# Altium schematic sheet I2C.SchDoc(I2C)
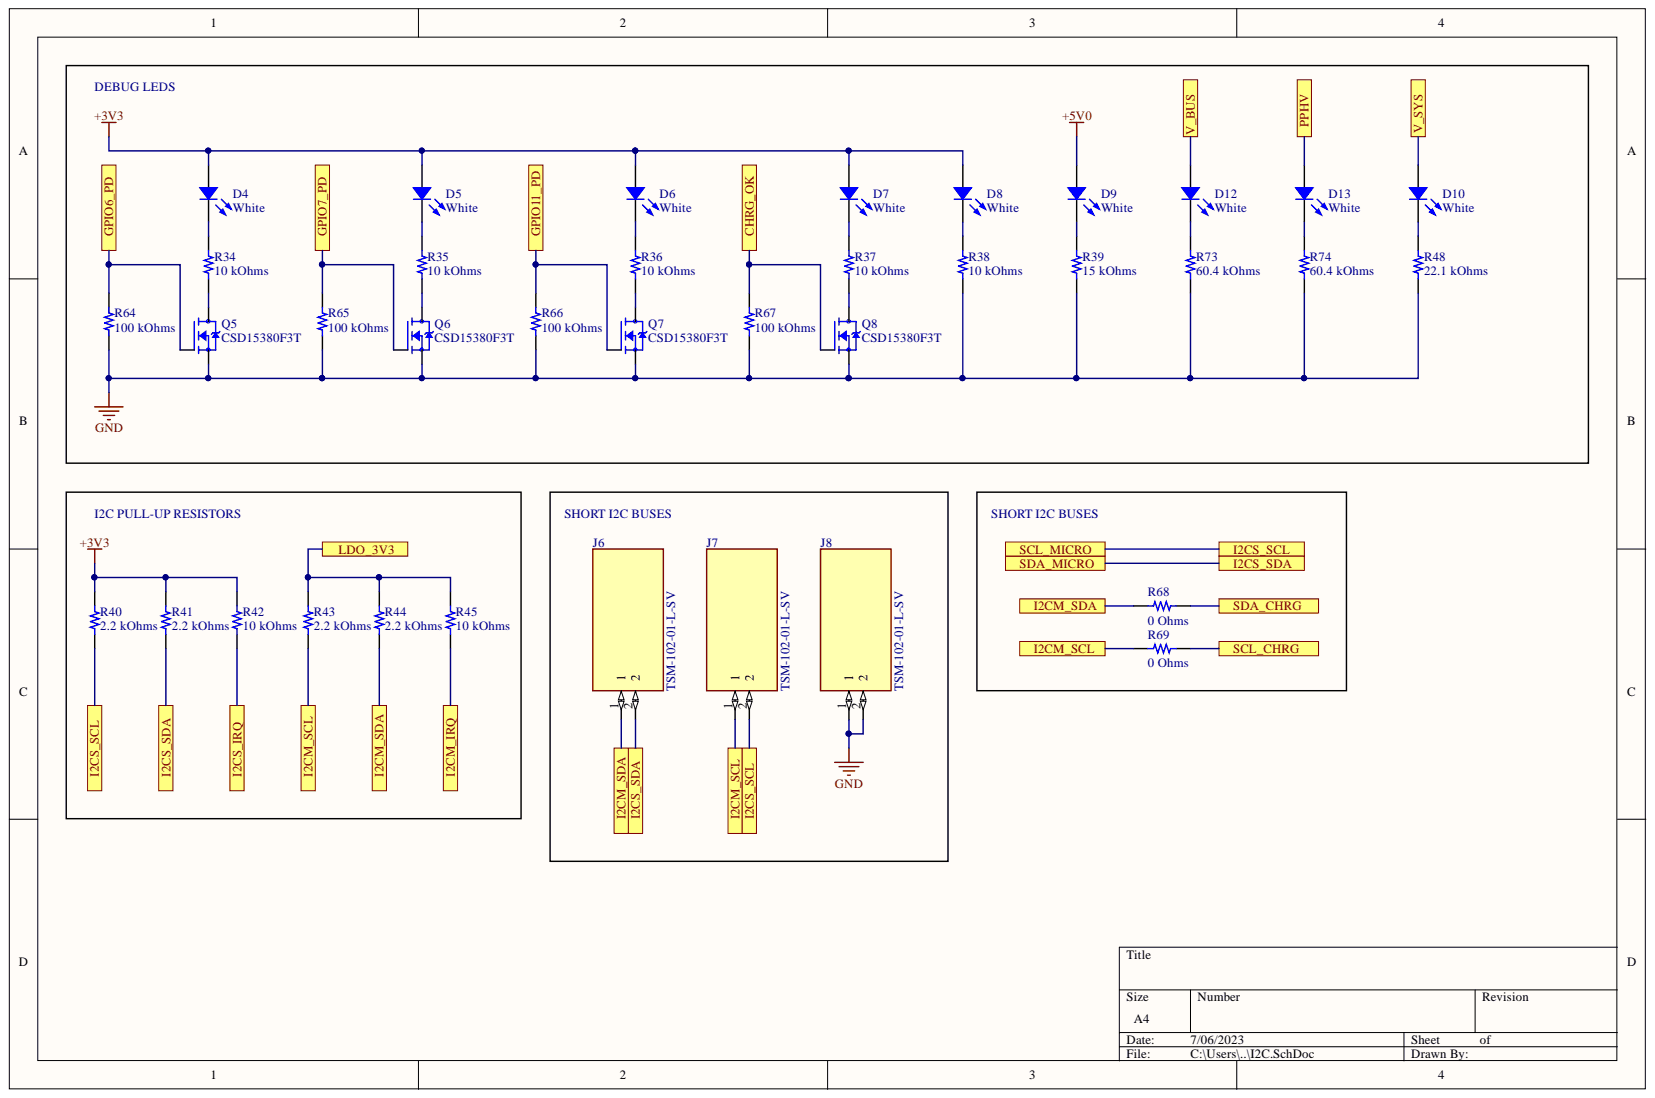
[diagram p0: I2C.SchDoc]

## Parts
D4  White  [LED 0805_2012]
D5  White  [LED 0805_2012]
D6  White  [LED 0805_2012]
D7  White  [LED 0805_2012]
D8  White  [LED 0805_2012]
D9  White  [LED 0805_2012]
D10  White  [LED 0805_2012]
D12  White  [LED 0805_2012]
D13  White  [LED 0805_2012]
J6  TSM-102-01-L-SV  [TSM-102-01-L-SV]
J7  TSM-102-01-L-SV  [TSM-102-01-L-SV]
J8  TSM-102-01-L-SV  [TSM-102-01-L-SV]
Q5  CSD15380F3T  [TRXDFN69X60X35-3N]
Q6  CSD15380F3T  [TRXDFN69X60X35-3N]
Q7  CSD15380F3T  [TRXDFN69X60X35-3N]
Q8  CSD15380F3T  [TRXDFN69X60X35-3N]
R34  10 kOhms  [RES 0603_1608]
R35  10 kOhms  [RES 0603_1608]
R36  10 kOhms  [RES 0603_1608]
R37  10 kOhms  [RES 0603_1608]
R38  10 kOhms  [RES 0603_1608]
R39  15 kOhms  [RES 0402_1005]
R40  2.2 kOhms  [RES 0402_1005]
R41  2.2 kOhms  [RES 0402_1005]
R42  10 kOhms  [RES 0402_1005]
R43  2.2 kOhms  [RES 0402_1005]
R44  2.2 kOhms  [RES 0402_1005]
R45  10 kOhms  [RES 0402_1005]
R48  22.1 kOhms  [RES 0603_1608]
R64  100 kOhms  [RES 0402_1005]
R65  100 kOhms  [RES 0402_1005]
R66  100 kOhms  [RES 0402_1005]
R67  100 kOhms  [RES 0402_1005]
R68  0 Ohms  [RES 0402_1005]
R69  0 Ohms  [RES 0402_1005]
R73  60.4 kOhms  [RES 0603_1608]
R74  60.4 kOhms  [RES 0603_1608]

## Ports
CHRG_OK, GPIO6_PD, GPIO7_PD, GPIO11_PD, I2CM_IRQ, I2CM_SCL, I2CM_SDA, I2CS_IRQ, I2CS_SCL, I2CS_SDA, LDO_3V3, PPHV, SCL_CHRG, SCL_MICRO, SDA_CHRG, SDA_MICRO, V_BUS, V_SYS

## Nets
+3V3: D4.1 D5.1 D6.1 D7.1 D8.1 R40.2 R41.2 R42.2
+5V0: D9.1
GND: J8.1 J8.2 Q5.2 Q6.2 Q7.2 Q8.2 R38.1 R39.1 R48.1 R64.1 R65.1 R66.1 R67.1 R73.1 R74.1
CHRG_OK: Q8.1 R67.2 ; port CHRG_OK
GPIO6_PD: Q5.1 R64.2 ; port GPIO6_PD
GPIO7_PD: Q6.1 R65.2 ; port GPIO7_PD
GPIO11_PD: Q7.1 R66.2 ; port GPIO11_PD
I2CM_IRQ: R45.1 ; port I2CM_IRQ
I2CM_SCL: J7.1 R43.1 R69.1 ; port I2CM_SCL
I2CM_SDA: J6.1 R44.1 R68.1 ; port I2CM_SDA
I2CS_IRQ: R42.1 ; port I2CS_IRQ
I2CS_SCL: J7.2 R40.1 ; port I2CS_SCL, port SCL_MICRO
I2CS_SDA: J6.2 R41.1 ; port I2CS_SDA, port SDA_MICRO
LDO_3V3: R43.2 R44.2 R45.2 ; port LDO_3V3
PPHV: D13.1 ; port PPHV
SCL_CHRG: R69.2 ; port SCL_CHRG
SDA_CHRG: R68.2 ; port SDA_CHRG
V_BUS: D12.1 ; port V_BUS
V_SYS: D10.1 ; port V_SYS
NetD4_2: D4.2 R34.2
NetD5_2: D5.2 R35.2
NetD6_2: D6.2 R36.2
NetD7_2: D7.2 R37.2
NetD8_2: D8.2 R38.2
NetD9_2: D9.2 R39.2
NetD10_2: D10.2 R48.2
NetD12_2: D12.2 R73.2
NetD13_2: D13.2 R74.2
NetQ5_3: Q5.3 R34.1
NetQ6_3: Q6.3 R35.1
NetQ7_3: Q7.3 R36.1
NetQ8_3: Q8.3 R37.1

## Library data of the parts
D4-D10 D12 D13 = QBLP631-IW5: 0805 LED WHITE, YELLOW DIFFUSED; Manufacturer: QT Brightek (QTB); Voltage - Forward (Vf) (Typ): 2.9V; Viewing Angle: 140°; Millicandela Rating: 85mcd; Lens Style: Rectangle with Flat Top; Lens Size: 1.30mm x 1.25mm; Database Table Name: LED - Indication; Current - Test: 5mA; Configuration: Standard; Value: White; Supplier Part Number 1: 1516-QBLP631-IW5CT-ND; Supplier Device Package: 0805; Supplier 1: DigiKey; Size / Dimension: 2.00mm L x 1.25mm W; Series: QBLP631; Price: 0.08; Part Status: Active; Part Number: QBLP631-IW5; PartId: 1097; Packaging: TapeAndReel; Package / Case: 0805 (2012 Metric); Mounting Type: Surface Mount; Minimum Order: 1; Lifecycle Status: Active; Library Name: Celestial Altium Library - altium_library.DbLib; Lens Transparency: Diffused; Lens Color: Yellow; LastUpdated: 9/08/2022 12:43:05 PM; Height (Max): 0.90mm; Device Package: 0805 (2012 Metric); ComponentLink2URL: https://www.digikey.com/en/products/detail/qt-brightek-qtb/QBLP631-IW5/16499651; ComponentLink2Description: DigiKey Link; ComponentLink1URL: https://www.qt-brightek.com/datasheet/QBLP631-IW5.pdf; ComponentLink1Description: Datasheet; Component Kind: Standard; Color: White
J6-J8 = TSM-102-01-L-SV: MF: {'manufacturer': u'Samtec Inc.'}; Price: None; Package: None; MP: TSM-102-01-L-SV; Component Kind: Standard; Availability: Unavailable
Q5-Q8 = CSD15380F3T: 20-V N-Channel FemtoFET MOSFET 3-PICOSTAR -55 to 150; MF: {'manufacturer': u'Texas Instruments'}; Price: None; Package: None; MP: CSD15380F3T; Component Kind: Standard; Availability: Unavailable
R34-R38 = CR0603-JW-103EAS: RES 10K OHM 5% 0.01W 0603; Manufacturer: Bourns Inc.; Temperature Coefficient: ±200ppm/°C; Database Table Name: Resistors - Surface Mount - 0603; Value: 10 kOhms; Tolerance: ±5%; Supplier Part Number 1: CR0603-JW-103EASCT-ND; Supplier Device Package: 0603; Supplier 1: DigiKey; Size / Dimension: 0.063" L x 0.031" W (1.60mm x 0.80mm); Series: CR0603-AS; Resistance: 10 kOhms; Price: 0.10; Power (Watts): 0.01W; Part Status: Active; Part Number: CR0603-JW-103EAS; PartId: 22277; Packaging: CutTape; Package / Case: 0603 (1608 Metric); Operating Temperature: -55°C ~ 155°C; Number of Terminations: 2; Minimum Order: 1; Lifecycle Status: Active; Library Name: Celestial Altium Library - altium_library.DbLib; LastUpdated: 27/04/2023 7:33:47 PM; Height - Seated (Max): 0.024" (0.60mm); Features: Anti-Sulfur; Device Package: 0603 (1608 Metric); Composition: Thick Film; ComponentLink2URL: http://digikey.com/product-detail/en/bourns-inc/CR0603-JW-103EAS/CR0603-JW-103EASCT-ND/6830121; ComponentLink2Description: DigiKey Link; ComponentLink1URL: https://www.bourns.com/docs/Product-Datasheets/CR0603-AS.pdf; ComponentLink1Description: Datasheet; Component Kind: Standard
R39 = RC0402FR-1315KL: RES 15K OHM 1% 1/16W 0402; Manufacturer: Yageo; Temperature Coefficient: ±100ppm/°C; Power (Watts): 0.063W, 1/16W; Database Table Name: Resistors - Surface Mount - 0402; Value: 15 kOhms; Tolerance: ±1%; Supplier Part Number 1: 13-RC0402FR-1315KLTR-ND; Supplier Device Package: 0402; Supplier 1: DigiKey; Size / Dimension: 0.039" L x 0.020" W (1.00mm x 0.50mm); Series: RC_L; Resistance: 15 kOhms; Price: 0; Part Status: Active; Part Number: RC0402FR-1315KL; PartId: 65094; Package / Case: 0402 (1005 Metric); Operating Temperature: -55°C ~ 155°C; Number of Terminations: 2; Minimum Order: 50000; Lifecycle Status: Active; Library Name: Celestial Altium Library - altium_library.DbLib; LastUpdated: 14/08/2022 9:22:52 PM; Height - Seated (Max): 0.016" (0.40mm); Features: Moisture Resistant; Device Package: 0402 (1005 Metric); Composition: Thick Film; ComponentLink2URL: https://www.digikey.com/en/products/detail/yageo/RC0402FR-1315KL/13694190; ComponentLink2Description: DigiKey Link; ComponentLink1URL: https://www.yageo.com/upload/media/product/productsearch/datasheet/rchip/PYu-RC_Group_51_RoHS_L_11.pdf; ComponentLink1Description: Datasheet; Component Kind: Standard
R40 R41 R43 R44 = RC0402FR-132K2L: RES 2.2K OHM 1% 1/16W 0402; Manufacturer: Yageo; Temperature Coefficient: ±100ppm/°C; Power (Watts): 0.063W, 1/16W; Database Table Name: Resistors - Surface Mount - 0402; Value: 2.2 kOhms; Tolerance: ±1%; Supplier Part Number 1: 13-RC0402FR-132K2LTR-ND; Supplier Device Package: 0402; Supplier 1: DigiKey; Size / Dimension: 0.039" L x 0.020" W (1.00mm x 0.50mm); Series: RC_L; Resistance: 2.2 kOhms; Price: 0; Part Status: Active; Part Number: RC0402FR-132K2L; PartId: 64946; Package / Case: 0402 (1005 Metric); Operating Temperature: -55°C ~ 155°C; Number of Terminations: 2; Minimum Order: 50000; Lifecycle Status: Active; Library Name: Celestial Altium Library - altium_library.DbLib; LastUpdated: 26/04/2023 7:19:35 PM; Height - Seated (Max): 0.016" (0.40mm); Features: Moisture Resistant; Device Package: 0402 (1005 Metric); Composition: Thick Film; ComponentLink2URL: https://www.digikey.com/en/products/detail/yageo/RC0402FR-132K2L/13694070; ComponentLink2Description: DigiKey Link; ComponentLink1URL: https://www.yageo.com/upload/media/product/productsearch/datasheet/rchip/PYu-RC_Group_51_RoHS_L_11.pdf; ComponentLink1Description: Datasheet; Component Kind: Standard
R42 R45 = RC0402JR-1310KL: RES 10K OHM 5% 1/16W 0402; Manufacturer: Yageo; Temperature Coefficient: ±100ppm/°C; Power (Watts): 0.063W, 1/16W; Database Table Name: Resistors - Surface Mount - 0402; Value: 10 kOhms; Tolerance: ±5%; Supplier Part Number 1: 13-RC0402JR-1310KLTR-ND; Supplier Device Package: 0402; Supplier 1: DigiKey; Size / Dimension: 0.039" L x 0.020" W (1.00mm x 0.50mm); Series: RC_L; Resistance: 10 kOhms; Price: 0; Part Status: Active; Part Number: RC0402JR-1310KL; PartId: 69237; Package / Case: 0402 (1005 Metric); Operating Temperature: -55°C ~ 155°C; Number of Terminations: 2; Minimum Order: 50000; Lifecycle Status: Active; Library Name: Celestial Altium Library - altium_library.DbLib; LastUpdated: 4/04/2023 7:21:31 PM; Height - Seated (Max): 0.016" (0.40mm); Features: Moisture Resistant; Device Package: 0402 (1005 Metric); Composition: Thick Film; ComponentLink2URL: https://www.digikey.com/en/products/detail/yageo/RC0402JR-1310KL/13694115; ComponentLink2Description: DigiKey Link; ComponentLink1URL: https://www.yageo.com/upload/media/product/productsearch/datasheet/rchip/PYu-RC_Group_51_RoHS_L_11.pdf; ComponentLink1Description: Datasheet; Component Kind: Standard
R48 = RMCF0603FT22K1: RES 22.1K OHM 1% 1/10W 0603; Manufacturer: Stackpole Electronics Inc; Temperature Coefficient: ±100ppm/°C; Power (Watts): 0.1W, 1/10W; Database Table Name: Resistors - Surface Mount - 0603; Value: 22.1 kOhms; Tolerance: ±1%; Supplier Part Number 1: RMCF0603FT22K1CT-ND; Supplier Device Package: 0603; Supplier 1: DigiKey; Size / Dimension: 0.061" L x 0.031" W (1.55mm x 0.80mm); Series: RMCF; Resistance: 22.1 kOhms; Ratings: AEC-Q200; Price: 0.01; Part Status: Active; Part Number: RMCF0603FT22K1; PartId: 6076; Packaging: CutTape; Package / Case: 0603 (1608 Metric); Operating Temperature: -55°C ~ 155°C; Number of Terminations: 2; Minimum Order: 1; Lifecycle Status: Active; Library Name: Celestial Altium Library - altium_library.DbLib; LastUpdated: 10/05/2023 7:38:01 PM; Height - Seated (Max): 0.022" (0.55mm); Features: Automotive AEC-Q200; Device Package: 0603 (1608 Metric); Composition: Thick Film; ComponentLink2URL: https://www.digikey.com/en/products/detail/stackpole-electronics-inc/RMCF0603FT22K1/1760764; ComponentLink2Description: DigiKey Link; ComponentLink1URL: https://www.seielect.com/catalog/sei-rmcf_rmcp.pdf; ComponentLink1Description: Datasheet; Component Kind: Standard
R64-R67 = RT0402FRE07100KL: RES SMD 100K OHM 1% 1/16W 0402; Manufacturer: Yageo; Temperature Coefficient: ±50ppm/°C; Power (Watts): 0.063W, 1/16W; Database Table Name: Resistors - Surface Mount - 0402; Value: 100 kOhms; Tolerance: ±1%; Supplier Part Number 1: YAG2307CT-ND; Supplier Device Package: 0402; Supplier 1: DigiKey; Size / Dimension: 0.039" L x 0.020" W (1.00mm x 0.50mm); Series: RT; Resistance: 100 kOhms; Price: 0.01; Part Status: Active; Part Number: RT0402FRE07100KL; PartId: 3190; Packaging: TapeAndReel; Package / Case: 0402 (1005 Metric); Operating Temperature: -55°C ~ 155°C; Number of Terminations: 2; Minimum Order: 1; Lifecycle Status: Active; Library Name: Celestial Altium Library - altium_library.DbLib; LastUpdated: 26/04/2023 7:20:40 PM; Height - Seated (Max): 0.014" (0.35mm); Device Package: 0402 (1005 Metric); Composition: Thin Film; ComponentLink2URL: https://www.digikey.com/en/products/detail/yageo/RT0402FRE07100KL/1071698; ComponentLink2Description: DigiKey Link; ComponentLink1URL: https://www.yageo.com/upload/media/product/productsearch/datasheet/rchip/PYu-RT_1-to-0.01_RoHS_L_11.pdf; ComponentLink1Description: Datasheet; Component Kind: Standard
R68 R69 = CRCW04020000Z0EDHP: RES SMD 0 OHM JUMPER 1/5W 0402; Manufacturer: Vishay Dale; Power (Watts): 0.2W, 1/5W; Database Table Name: Resistors - Surface Mount - 0402; Value: 0 Ohms; Tolerance: Jumper; Supplier Part Number 1: 541-0.0YBCT-ND; Supplier Device Package: 0402; Supplier 1: DigiKey; Size / Dimension: 0.039" L x 0.020" W (1.00mm x 0.50mm); Series: CRCW-HP; Resistance: 0 Ohms; Ratings: AEC-Q200; Price: 0.01; Part Status: Active; Part Number: CRCW04020000Z0EDHP; PartId: 3131; Packaging: TapeAndReel; Package / Case: 0402 (1005 Metric); Operating Temperature: -55°C ~ 155°C; Number of Terminations: 2; Minimum Order: 1; Lifecycle Status: Active; Library Name: Celestial Altium Library - altium_library.DbLib; LastUpdated: 27/04/2023 7:26:03 PM; Height - Seated (Max): 0.016" (0.40mm); Features: Automotive AEC-Q200, Pulse Withstanding; Device Package: 0402 (1005 Metric); Composition: Thick Film; ComponentLink2URL: https://www.digikey.com/en/products/detail/vishay-dale/CRCW04020000Z0EDHP/2222496; ComponentLink2Description: DigiKey Link; ComponentLink1URL: http://www.vishay.com/docs/20043/crcwhpe3.pdf; ComponentLink1Description: Datasheet; Component Kind: Standard
R73 R74 = CRCW060360K4FKEA: RES SMD 60.4K OHM 1% 1/10W 0603; Manufacturer: Vishay Dale; Temperature Coefficient: ±100ppm/°C; Power (Watts): 0.1W, 1/10W; Database Table Name: Resistors - Surface Mount - 0603; Value: 60.4 kOhms; Tolerance: ±1%; Supplier Part Number 1: 541-60.4KHCT-ND; Supplier Device Package: 0603; Supplier 1: DigiKey; Size / Dimension: 0.061" L x 0.033" W (1.55mm x 0.85mm); Series: CRCW; Resistance: 60.4 kOhms; Ratings: AEC-Q200; Price: 0.01; Part Status: Active; Part Number: CRCW060360K4FKEA; PartId: 5182; Packaging: CutTape; Package / Case: 0603 (1608 Metric); Operating Temperature: -55°C ~ 155°C; Number of Terminations: 2; Minimum Order: 1; Lifecycle Status: Active; Library Name: Celestial Altium Library - altium_library.DbLib; LastUpdated: 7/05/2023 7:36:13 PM; Height - Seated (Max): 0.020" (0.50mm); Features: Automotive AEC-Q200; Device Package: 0603 (1608 Metric); Composition: Thick Film; ComponentLink2URL: https://www.digikey.com/en/products/detail/vishay-dale/CRCW060360K4FKEA/1174871; ComponentLink2Description: DigiKey Link; ComponentLink1URL: http://www.vishay.com/docs/20035/dcrcwe3.pdf; ComponentLink1Description: Datasheet; Component Kind: Standard
